# Altium schematic sheet Power_Measurement.SchDoc(Power_Measurement)
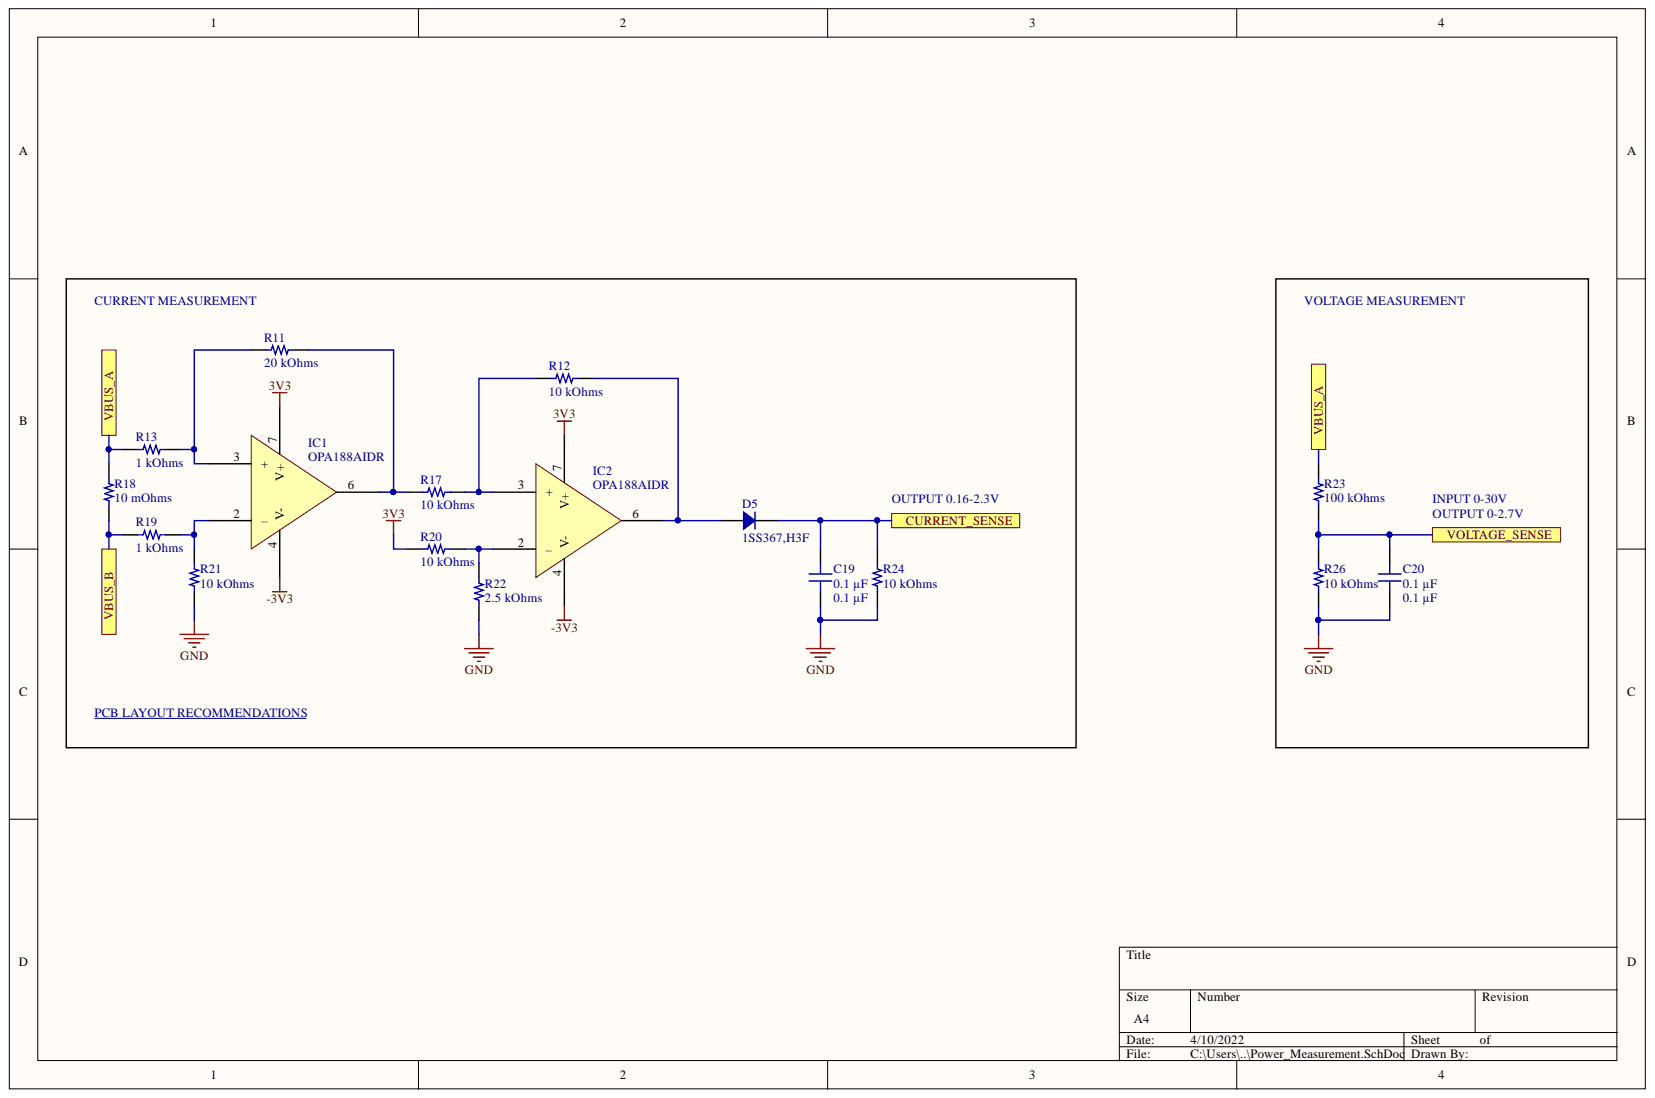
[diagram p0: Power_Measurement.SchDoc]

## Parts
C19  0.1 µF  [CAP 0603_1608]
C20  0.1 µF  [CAP 0603_1608]
D5  1SS367,H3F  [SOD323]
IC1  OPA188AIDR  [TI SOIC-8 D]
IC2  OPA188AIDR  [TI SOIC-8 D]
R11  20 kOhms  [RES 0603_1608]
R12  10 kOhms  [RES 0603_1608]
R13  1 kOhms  [RES 0603_1608]
R17  10 kOhms  [RES 0603_1608]
R18  10 mOhms  [STACKPOLE CSR1206]
R19  1 kOhms  [RES 0603_1608]
R20  10 kOhms  [RES 0603_1608]
R21  10 kOhms  [RES 0603_1608]
R22  2.5 kOhms  [RES 0603_1608]
R23  100 kOhms  [RES 0603_1608]
R24  10 kOhms  [RES 0603_1608]
R26  10 kOhms  [RES 0603_1608]

## Ports
CURRENT_SENSE, VBUS_A, VBUS_B, VOLTAGE_SENSE

## Nets
3V3: IC1.7(V+) IC2.7(V+) R20.2
-3V3: IC1.4(V-) IC2.4(V-)
GND: C19.1 C20.1 R21.1 R22.1 R24.2 R26.1
CURRENT_SENSE: C19.2 D5.2 R24.1 ; port CURRENT_SENSE
VBUS_A: R13.2 R18.2 R23.2 ; port VBUS_A
VBUS_B: R18.1 R19.2 ; port VBUS_B
VOLTAGE_SENSE: C20.2 R23.1 R26.2 ; port VOLTAGE_SENSE
NetD5_1: D5.1 IC2.6 R12.1
NetIC1_2: IC1.2(–) R19.1 R21.2
NetIC1_3: IC1.3(+) R11.1 R13.1
NetIC1_6: IC1.6 R11.2 R17.2
NetIC2_2: IC2.2(–) R20.1 R22.2
NetIC2_3: IC2.3(+) R12.2 R17.1

## Library data of the parts
C19 C20 = C0603C104K5RAC7411: CAP CER 0.1UF 50V X7R 0603; manufacturer: KEMET; Tolerance: ±10%; Database Table Name: Capacitors - Ceramic - 0603; Voltage - Rated: 50V; Value: 0.1 µF; Thickness (Max): 0.035" (0.90mm); Temperature Coefficient: X7R; Supplier Part Number 1: 399-7374-2-ND; Supplier 1: DigiKey; Size / Dimension: 0.063" L x 0.031" W (1.60mm x 0.80mm); series: SMD Comm X7R; price: 0.01; Part Status: Active; Part Number: C0603C104K5RAC7411; partid: 2233; packaging: TapeAndReel; Package / Case: 0603 (1608 Metric); Operating Temperature: -55°C ~ 125°C; Mounting Type: Surface Mount, MLCC; Minimum Order: 15000; Lifecycle Status: Active; Library Name: Celestial Altium Library - altium_library.DbLib; lastupdated: 21/08/2022 8:00:52 PM; Device Package: 0603 (1608 Metric); componentlink2url: https://www.digikey.com/en/products/detail/kemet/C0603C104K5RAC7411/3317013; componentlink2description: DigiKey Link; componentlink1url: https://api.kemet.com/component-edge/download/datasheet/C0603C104K5RAC7411.pdf; componentlink1description: Datasheet; Component Kind: Standard; Capacitance: 0.1 µF; Applications: Bypass, Decoupling
D5 = 1SS367,H3F: DIODE SCHOTTKY 10V 100MA SC76; Manufacturer: Toshiba Semiconductor and Storage; Voltage - Forward (Vf) (Max) @ If: 500 mV @ 100 mA; Speed: Small Signal =< 200mA (Io), Any Speed; Database Table Name: Diodes - Rectifiers - Single; Current - Reverse Leakage @ Vr: 20 µA @ 10 V; Capacitance @ Vr, F: 40pF @ 0V, 1MHz; Voltage - DC Reverse (Vr) (Max): 10 V; Supplier Part Number 1: 1SS367H3FCT-ND; Supplier Device Package: USC; Supplier 1: DigiKey; Series: -; Price: 0.02; Part Status: Active; Part Number: 1SS367,H3F; PartId: 2994; Packaging: TapeAndReel; Package / Case: SC-76, SOD-323; Operating Temperature - Junction: 125°C (Max); Mounting Type: Surface Mount; Minimum Order: 1; Lifecycle Status: Active; Library Name: Celestial Altium Library - altium_library.DbLib; LastUpdated: 30/08/2022 2:45:55 PM; Diode Type: Schottky; Current - Average Rectified (Io): 100mA; ComponentLink2URL: https://www.digikey.com/en/products/detail/toshiba-semiconductor-and-storage/1SS367-H3F/4304874; ComponentLink2Description: DigiKey Link; ComponentLink1URL: https://toshiba.semicon-storage.com/info/docget.jsp?did=3342&prodName=1SS367; ComponentLink1Description: Datasheet; Component Kind: Standard
IC1 IC2 = OPA188AIDR: IC OPAMP ZERO-DRIFT 1 CIRC 8SOIC; Manufacturer: Texas Instruments; Voltage - Supply, Single/Dual (±): 4V ~ 36V, ±2V ~ 18V; Voltage - Input Offset: 6µV; Slew Rate: 0.8V/µs; Output Type: Rail-to-Rail; Number of Circuits: 1; Gain Bandwidth Product: 2MHz; Database Table Name: Amplifiers - OP Amps and Buffer Amps; Current - Supply: 450µA; Current - Input Bias: 160pA; Supplier Part Number 1: 296-41346-1-ND; Supplier Device Package: 8-SOIC; Supplier 1: DigiKey; Series: -; Price: 1.05; Part Status: Active; Part Number: OPA188AIDR; PartId: 36; Packaging: TapeAndReel; Package / Case: 8-SOIC (0.154", 3.90mm Width); Operating Temperature: -55°C ~ 150°C; Mounting Type: Surface Mount; Minimum Order: 1; Lifecycle Status: Active; Library Name: Celestial Altium Library - altium_library.DbLib; LastUpdated: 29/08/2020 7:13:35 PM; ComponentLink2URL: http://digikey.com/product-detail/en/texas-instruments/OPA188AIDR/296-41346-1-ND/5222828; ComponentLink2Description: DigiKey Link; ComponentLink1URL: http://www.ti.com/general/docs/suppproductinfo.tsp?distId=10&gotoUrl=http%3A%2F%2Fwww.ti.com%2Flit%2Fgpn%2Fopa188; ComponentLink1Description: Datasheet; Component Kind: Standard; Amplifier Type: Zero-Drift
R11 = ERA-3AEB203V: RES SMD 20K OHM 0.1% 1/10W 0603; Manufacturer: Panasonic Electronic Components; Temperature Coefficient: ±25ppm/°C; Power (Watts): 0.1W, 1/10W; Database Table Name: Resistors - Surface Mount - 0603; Value: 20 kOhms; Tolerance: ±0.1%; Supplier Part Number 1: P20KDBCT-ND; Supplier Device Package: 0603; Supplier 1: DigiKey; Size / Dimension: 0.063" L x 0.031" W (1.60mm x 0.80mm); Series: ERA-3A; Resistance: 20 kOhms; Ratings: AEC-Q200; Price: 0.04; Part Status: Active; Part Number: ERA-3AEB203V; PartId: 5521; Packaging: TapeAndReel; Package / Case: 0603 (1608 Metric); Operating Temperature: -55°C ~ 155°C; Number of Terminations: 2; Minimum Order: 1; Lifecycle Status: Active; Library Name: Celestial Altium Library - altium_library.DbLib; LastUpdated: 27/08/2022 7:54:11 AM; Height - Seated (Max): 0.022" (0.55mm); Features: Automotive AEC-Q200; Device Package: 0603 (1608 Metric); Composition: Thin Film; ComponentLink2URL: https://www.digikey.com/en/products/detail/panasonic-electronic-components/ERA-3AEB203V/1465885; ComponentLink2Description: DigiKey Link; ComponentLink1URL: https://industrial.panasonic.com/cdbs/www-data/pdf/RDM0000/AOA0000C307.pdf; ComponentLink1Description: Datasheet; Component Kind: Standard
R12 R17 R20 R21 R24 R26 = RNCS0603BKE10K0: RES 10K OHM 0.1% 1/16W 0603; Manufacturer: Stackpole Electronics Inc; Temperature Coefficient: ±25ppm/°C; Power (Watts): 0.063W, 1/16W; Database Table Name: Resistors - Surface Mount - 0603; Value: 10 kOhms; Tolerance: ±0.1%; Supplier Part Number 1: RNCS0603BKE10K0CT-ND; Supplier Device Package: 0603; Supplier 1: DigiKey; Size / Dimension: 0.061" L x 0.031" W (1.55mm x 0.80mm); Series: RNCS; Resistance: 10 kOhms; Ratings: AEC-Q200; Price: 0.08; Part Status: Active; Part Number: RNCS0603BKE10K0; PartId: 4838; Packaging: TapeAndReel; Package / Case: 0603 (1608 Metric); Operating Temperature: -55°C ~ 155°C; Number of Terminations: 2; Minimum Order: 1; Lifecycle Status: Active; Library Name: Celestial Altium Library - altium_library.DbLib; LastUpdated: 27/08/2022 7:54:13 AM; Height - Seated (Max): 0.022" (0.55mm); Features: Anti-Corrosive, Automotive AEC-Q200, Moisture Resistant; Device Package: 0603 (1608 Metric); Composition: Thin Film; ComponentLink2URL: https://www.digikey.com/en/products/detail/stackpole-electronics-inc/RNCS0603BKE10K0/1708836; ComponentLink2Description: DigiKey Link; ComponentLink1URL: https://www.seielect.com/catalog/sei-rncs_rnch.pdf; ComponentLink1Description: Datasheet; Component Kind: Standard
R13 R19 = CPF0603B1K0E: RES SMD 1K OHM 0.1% 1/16W 0603; Manufacturer: TE Connectivity Passive Product; Temperature Coefficient: ±25ppm/°C; Power (Watts): 0.063W, 1/16W; Database Table Name: Resistors - Surface Mount - 0603; Value: 1 kOhms; Tolerance: ±0.1%; Supplier Part Number 1: A119887CT-ND; Supplier Device Package: 0603; Supplier 1: DigiKey; Size / Dimension: 0.061" L x 0.031" W (1.55mm x 0.80mm); Series: CPF, Neohm; Resistance: 1 kOhms; Price: 0.09; Part Status: Active; Part Number: CPF0603B1K0E; PartId: 4877; Packaging: TapeAndReel; Package / Case: 0603 (1608 Metric); Operating Temperature: -55°C ~ 155°C; Number of Terminations: 2; Minimum Order: 1; Lifecycle Status: Active; Library Name: Celestial Altium Library - altium_library.DbLib; LastUpdated: 27/08/2022 7:54:13 AM; Height - Seated (Max): 0.022" (0.55mm); Device Package: 0603 (1608 Metric); Composition: Thin Film; ComponentLink2URL: https://www.digikey.com/en/products/detail/te-connectivity-passive-product/CPF0603B1K0E/2390473; ComponentLink2Description: DigiKey Link; ComponentLink1URL: https://www.te.com/commerce/DocumentDelivery/DDEController?Action=srchrtrv&DocNm=1773200&DocType=DS&DocLang=English; ComponentLink1Description: Datasheet; Component Kind: Standard
R18 = FC4TR010DER: RES 0.01 OHM 0.5% 1/2W 1206; Manufacturer: Ohmite; Temperature Coefficient: ±50ppm/°C; Power (Watts): 0.5W, 1/2W; Database Table Name: Resistors - Current Sense SMT; Value: 10 mOhms; Tolerance: ±0.5%; Supplier Part Number 1: FC4TR010DERCT-ND; Supplier Device Package: 1206; Supplier 1: DigiKey; Size / Dimension: 0.126" L x 0.063" W (3.20mm x 1.60mm); Series: FC4T; Resistance: 10 mOhms; Price: 0.23; Part Status: Active; Part Number: FC4TR010DER; PartId: 2219; Packaging: TapeAndReel; Package / Case: 1206 (3216 Metric); Operating Temperature: -55°C ~ 155°C; Number of Terminations: 4; Minimum Order: 1; Lifecycle Status: Active; Library Name: Celestial Altium Library - altium_library.DbLib; LastUpdated: 25/08/2022 7:31:23 AM; Height - Seated (Max): 0.031" (0.80mm); Features: Current Sense; Device Package: 1206 (3216 Metric); Composition: Metal Foil; ComponentLink2URL: https://www.digikey.com/en/products/detail/ohmite/FC4TR010DER/7918914; ComponentLink2Description: DigiKey Link; ComponentLink1URL: http://www.ohmite.com/assets/docs/res_fc4t.pdf?r=false; ComponentLink1Description: Datasheet; Component Kind: Standard
R22 = PCAN0603E2501BST5: RES SMD 2.5K OHM 0.1% 1/2W 0603; Manufacturer: Vishay Thin Film; Temperature Coefficient: ±25ppm/°C; Power (Watts): 0.5W, 1/2W; Database Table Name: Resistors - Surface Mount - 0603; Value: 2.5 kOhms; Tolerance: ±0.1%; Supplier Part Number 1: 764-1254-1-ND; Supplier Device Package: 0603; Supplier 1: DigiKey; Size / Dimension: 0.064" L x 0.032" W (1.63mm x 0.81mm); Series: PCAN; Resistance: 2.5 kOhms; Price: 0.54; Part Status: Active; Part Number: PCAN0603E2501BST5; PartId: 18728; Packaging: TapeAndReel; Package / Case: 0603 (1608 Metric); Operating Temperature: -55°C ~ 155°C; Number of Terminations: 2; Minimum Order: 1; Lifecycle Status: Active; Library Name: Celestial Altium Library - altium_library.DbLib; LastUpdated: 27/08/2022 7:53:20 AM; Height - Seated (Max): 0.018" (0.46mm); Features: Flame Proof, Moisture Resistant, Safety; Device Package: 0603 (1608 Metric); Composition: Thin Film; ComponentLink2URL: http://digikey.com/product-detail/en/vishay-thin-film/PCAN0603E2501BST5/764-1254-1-ND/6003328; ComponentLink2Description: DigiKey Link; ComponentLink1URL: http://www.vishay.com/docs/60125/pcan.pdf; ComponentLink1Description: Datasheet; Component Kind: Standard
R23 = RNCS0603BKE100K: RES 100K OHM 0.1% 1/16W 0603; Manufacturer: Stackpole Electronics Inc; Temperature Coefficient: ±25ppm/°C; Power (Watts): 0.063W, 1/16W; Database Table Name: Resistors - Surface Mount - 0603; Value: 100 kOhms; Tolerance: ±0.1%; Supplier Part Number 1: RNCS0603BKE100KCT-ND; Supplier Device Package: 0603; Supplier 1: DigiKey; Size / Dimension: 0.061" L x 0.031" W (1.55mm x 0.80mm); Series: RNCS; Resistance: 100 kOhms; Ratings: AEC-Q200; Price: 0.08; Part Status: Active; Part Number: RNCS0603BKE100K; PartId: 4849; Packaging: TapeAndReel; Package / Case: 0603 (1608 Metric); Operating Temperature: -55°C ~ 155°C; Number of Terminations: 2; Minimum Order: 1; Lifecycle Status: Active; Library Name: Celestial Altium Library - altium_library.DbLib; LastUpdated: 30/08/2022 2:09:04 PM; Height - Seated (Max): 0.022" (0.55mm); Features: Anti-Corrosive, Automotive AEC-Q200, Moisture Resistant; Device Package: 0603 (1608 Metric); Composition: Thin Film; ComponentLink2URL: https://www.digikey.com/en/products/detail/stackpole-electronics-inc/RNCS0603BKE100K/1708835; ComponentLink2Description: DigiKey Link; ComponentLink1URL: https://www.seielect.com/catalog/sei-rncs_rnch.pdf; ComponentLink1Description: Datasheet; Component Kind: Standard
